# Altium schematic sheet PowerModule.SchDoc(PowerModule)
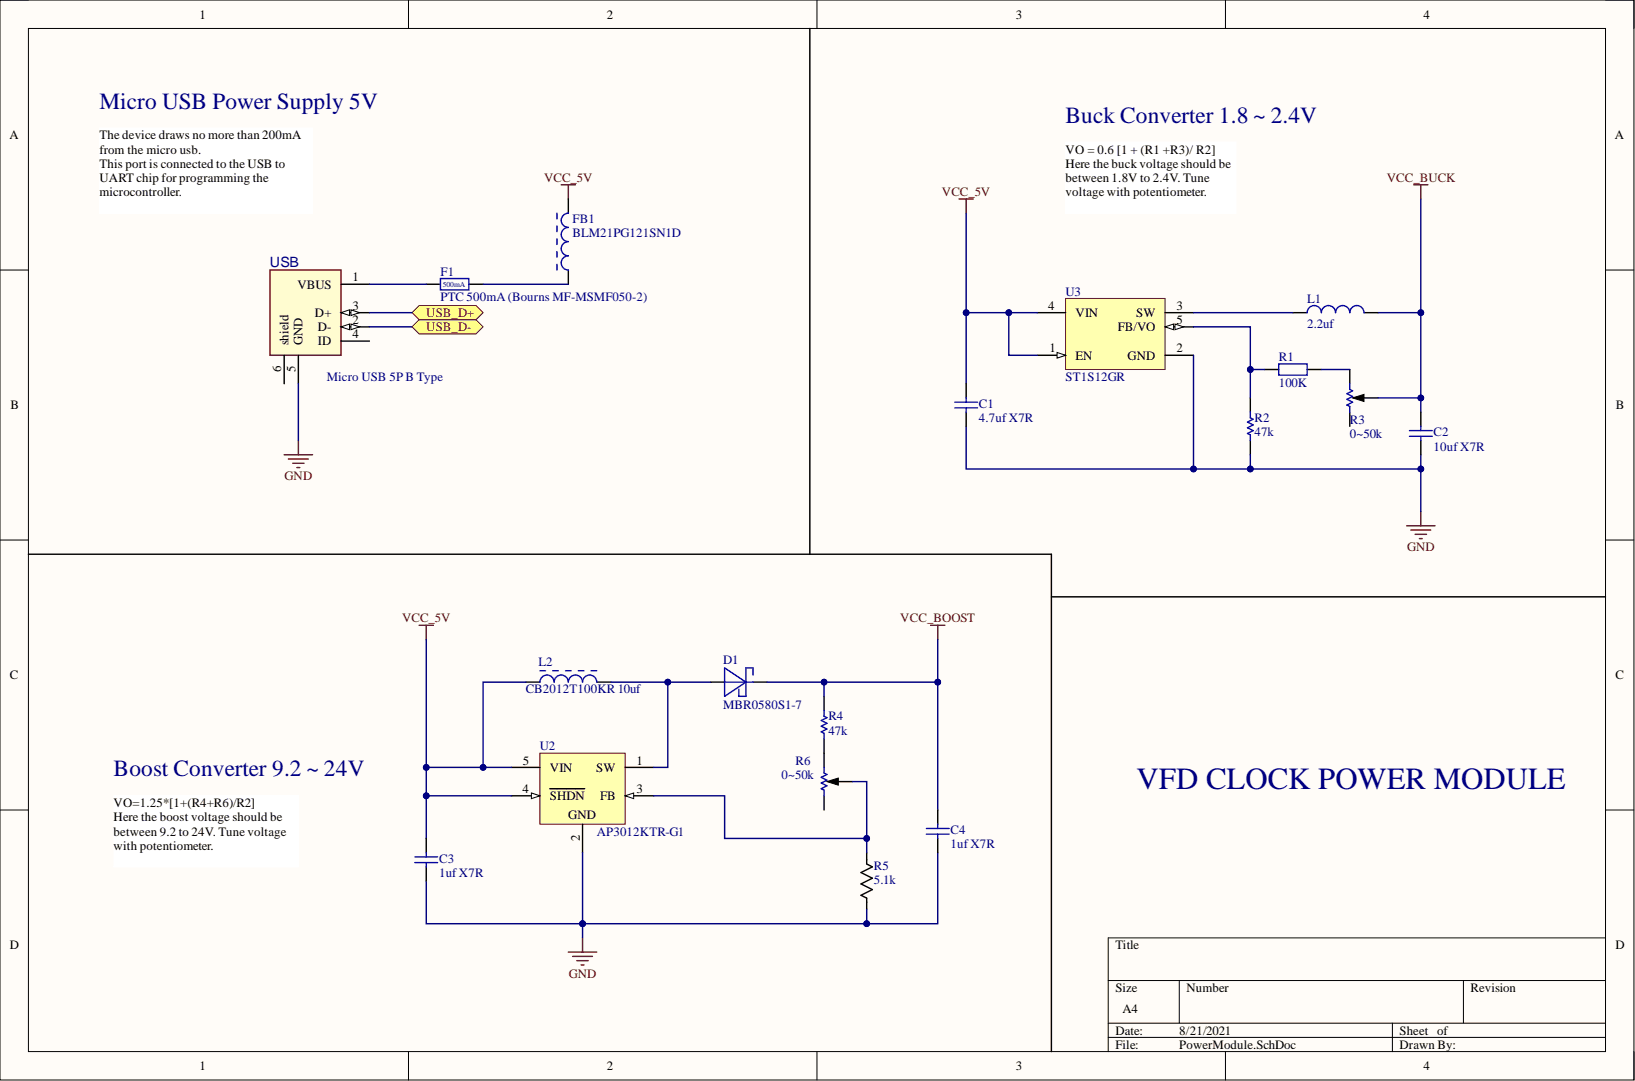
[diagram p0: PowerModule.SchDoc]

## Parts
C1  4.7uf X7R  [PCB-xrh88671zmf2jss4mnlt-1]
C2  10uf X7R  [PCB-xrh88671zmf2jss4mnlt-1]
C3  1uf X7R  Dielectric Characteristic: X7R  [PCB-xrh88671zmf2jss4mnlt-1]
C4  1uf X7R  Dielectric Characteristic: X7R  [PCB-xrh88671zmf2jss4mnlt-1]
D1  MBR0580S1-7  [PCB-x9u2r4ha3xm1g26stesz-1]
F1  PTC 500mA (Bourns MF-MSMF050-2)  [PCB-jtgmhik4xw0hzz22xc7c-1]
FB1  BLM21PG121SN1D  [PCB-2tlhtcllslu2k50oule1-1]
L1  2.2uf  [PCB-x314rydh028eodagaqn6-1]
L2  CB2012T100KR 10uf  [PCB-azxz2krz5wef70fntus1-1]
R1  100K  [PCB-3656032-1]
R2  47k  [PCB-b4z3kml9vja5p4iafh7f-1]
R3  0~50k  [PCB-bw0neadmiyqt3ahpjs36-1]
R4  47k  [PCB-0002-00377-5]
R5  5.1k  [PCB-0002-00380-1]
R6  0~50k  [PCB-bw0neadmiyqt3ahpjs36-1]
U2  AP3012KTR-G1  [PCB-9ejrgpl03flg5xvo1qej-1]
U3  ST1S12GR  [TSOT23-5L_M]
USB  Micro USB 5P B Type  [PCB-edlm2od7brxgpj78b9fm-1]

## Ports
USB_D+, USB_D-

## Nets
GND: C1.1 C2.1 C3.1 C4.1 R2.1 R5.1 U2.2 U3.2(GND) USB.5(GND)
VCC_5V: C1.2 C3.2 FB1.2 L2.1 U2.4(SHDN) U2.5(VIN) U3.1(EN) U3.4(VIN)
VCC_BOOST: C4.2 D1.1 R4.2
VCC_BUCK: C2.2 L1.2 R3.2
NetD1_2: D1.2 L2.2 U2.1(SW)
NetF1_1: F1.1 USB.1(VBUS)
NetF1_2: F1.2 FB1.1
NetL1_1: L1.1 U3.3(SW)
NetR1_1: R1.1 R2.2 U3.5(FB/VO)
NetR1_2: R1.2 R3.1
NetR4_1: R4.1 R6.1
NetR5_2: R5.2 R6.2 U2.3(FB)
NetUSB_2: USB.2(D-)
NetUSB_3: USB.3(D+)

Not connected: R3 3; R6 3; USB 4(ID) 6(shield)

## Library data of the parts
C1-C4 = CMP-7104bbe86e53aec3-2: Capacitor [Würth Elektronik] 885012207051 Capacitor; Capacitance: 1.00 µF; Capacitance Tolerance: ±10%; Voltage Rating (DC): 16.0 V; Component Kind: Standard; Library Name: My Personal Space; Manufacturer Part Number: 885012207051; Octopart URL: https://octopart.com/885012207051-w%C3%BCrth+elektronik-65419825; OctopartID: 7104bbe86e53aec3; Packaging: Cut Tape (CT); RoHS: Compliant
  C1 C2: Dielectric Characteristic: X7R
D1 = CMP-f9c833eb294a260f-2: Diode Schottky Barrier Rectifier 80V 0.5A 2-Pin SOD123 T/R; Component Kind: Standard; Library Name: My Personal Space; Lifecycle Status: Not Listed by Manufacturer; Manufacturer Part Number: MBR0580S1-7; Mounting Style: Surface Mount; Octopart URL: https://octopart.com/mbr0580s1-7-diodes+inc.-53081572; OctopartID: f9c833eb294a260f; Packaging: Tape & Reel (TR)
F1 = CMP-277735-10: PTC Resettable Fuse 0.5A(hold) 1A(trip) 15V 100A 0.8W 0.15s 0.15Ohm SMD Solder Pad 1812 T/R; Case/Package (SI): 4532; Lead-Free Status: Lead Free; Operating Voltage: 15.0 V; Power Dissipation: 800 mW; Resistance: 150 mΩ; Time to Trip: 150 ms (max); Tripping Current: 1.00 A; Case/Package: 1812; Component Kind: Standard; Current Rating: 100 A; Digikey: MF-MSMF050-2CT-ND; Holding Current: 500 mA; Library Name: My Personal Space; Manufacturer Part Number: MF-MSMF050-2; Mounting Style: Surface Mount; Octopart URL: https://octopart.com/mf-msmf050-2-bourns-28047; OctopartID: 9a15531fd2fc45d2; Operating Temperature: 85.0 °C (max); Packaging: Tape & Reel (TR), Cut Tape (CT); REACH SVHC Compliance: No SVHC; RoHS: Compliant; Size-Height: 850 µm; Size-Length: 4.55 mm; Size-Width: 3.41 mm; Voltage Rating (DC): 15.0 V
FB1 = CMP-2312463-4: Chip Ferrite Bead for Power Lines, 120 Ohm, 3000 mA, -55 to 125 degC, 2 x 1.25 x 1.05 mm SMD, Tape and Reel; Lead-Free Status: Lead Free; Number of Circuits: 1; Resistance: 120.0 Ω; Component Kind: Standard; Library Name: My Personal Space; Manufacturer Part Number: BLM21PG121SN1D; Octopart Url: https://octopart.com/blm21pg121sn1d-murata-17751448; OctopartID: 2965e161d19bf6a2; REACH SVHC Compliance: No SVHC; RoHS: Compliant; Size-Length: 0.002 m; Size-Width: 0.00125 m
L1 = CMP-b06c7f77ff336fab-1: Bourns CVH201205-2R2M  Inductor Power Shielded Multi-Layer 2.2uH 20% 1MHz Ferrite 0.6A 0.33Ohm DCR 0805 T/R; Component Kind: Standard; Library Name: My Personal Space; Manufacturer Part Number: CVH201205-2R2M; Octopart Url: https://octopart.com/cvh201205-2r2m-bourns-101804601; OctopartID: b06c7f77ff336fab
L2 = CMP-b3277b0f1b366ce0-1: Inductor Power Chip Wirewound 68uH 10% 2.52MHz Ferrite 140mA 7.8Ohm DCR 0805 T/R; Manufacturer Part Number: CB2012T680K; Inductance: 68.0 µH; Lead-Free Status: Lead Free; Self Resonant Frequency: 10.0 MHz; Case/Package: 0805; Component Kind: Standard; Halogen Free Status: Halogen Free; Library Name: My Personal Space; Lifecycle Status: Active; Mounting Style: Surface Mount; Number of Pins: 2; Octopart URL: https://octopart.com/cb2012t680k-taiyo+yuden-19041655; OctopartID: b3277b0f1b366ce0; Packaging: Reel; RoHS: Compliant
R1 = CMP-3656032-9: Thick Film Resistors - SMD 100K 1%; Manufacturer Part Number: CR0805-FX-1003ELF; Case/Package (SI): 2012; Power Rating: 0.125 W; Resistance: 100000.0 Ω; Voltage Rating (DC): 100.0 V; Case/Package: 0805; Component Kind: Standard; Library Name: My Personal Space; Mounting Style: Surface Mount; Number of Pins: 2; Octopart Url: https://octopart.com/cr0805-fx-1003elf-bourns-982211; OctopartID: b2b07bb46b156d77; REACH SVHC Compliance: No SVHC; Resistance Tolerance: ±1%; RoHS: Compliant; Size-Length: 0.0020066 m; Size-Thickness: 0.000508 m; Size-Width: 0.0012446 m
R2 = CMP-39acf6c9017ee66b-1: Resistor; ResHighPower 0805 330R 1% 1/2W TC100; Manufacturer Part Number: CMP0805-FX-3300ELF; Value: 330 Ω; Component Kind: Standard; Library Name: My Personal Space; Octopart URL: https://octopart.com/cmp0805-fx-3300elf-bourns-107945396; OctopartID: 39acf6c9017ee66b
R3 R6 = CMP-13cdd9aad93acc0a-3: Res Cermet Trimmer 50K Ohm 10% 1/2W 1(Elec)/1(Mech)Turn 2.6mm (7 X 7 X 5.8mm) Pin Thru-Hole Bulk; Manufacturer Part Number: CT-6EP503; Lead-Free Status: Lead Free; Power Rating: 500 mW; Resistance: 50.0 kΩ; Temperature Coefficient: -100 ppm/°C to 100 ppm/°C; Color: Blue; Component Kind: Standard; Library Name: My Personal Space; Mounting Style: Through Hole; Octopart URL: https://octopart.com/ct-6ep503-nidec+copal-91739835; OctopartID: 99aa55d76a77466d; Operating Temperature: 120 °C (max); Packaging: Bulk; Resistance Tolerance: ±10%; RoHS: Compliant; Size-Height: 5.80 mm; Size-Length: 7.00 mm; Size-Width: 7.00 mm; Weight: 510 mg
R4 = CMP-0eb2c5cc96c2d175-6: Res Thick Film 0805 47K Ohm 1% 1/8W ±100ppm/°C Molded SMD SMD Paper T/R; Manufacturer Part Number: RC0805FR-0747KL; Case/Package (SI): 2012; Lead-Free Status: Lead Free; Power Rating: 125 mW; Resistance: 47.0 kΩ; Temperature Coefficient: -100 ppm/°C to 100 ppm/°C; Voltage Rating (DC): 150 V; Case/Package: 0805; Component Kind: Standard; Library Name: My Personal Space; Mounting Style: Surface Mount; Number of Pins: 2; Octopart URL: https://octopart.com/rc0805fr-0747kl-yageo-41205014; OctopartID: 0eb2c5cc96c2d175; Operating Temperature: 155 °C (max); Packaging: Tape & Reel; REACH SVHC Compliance: No SVHC; Resistance Tolerance: ±1%; RoHS: Compliant; Size-Height: 500 µm; Size-Length: 2.00 mm; Size-Width: 1.25 mm
R5 = CMP-9b27523ffbe444e0-4: Res Thick Film 0805 5.1K Ohm 1% 0.125W(1/8W) ±100ppm/C Epoxy Pad SMD T/R; Manufacturer Part Number: RC0805FR-075K1L; Case/Package (SI): 2012; Lead-Free Status: Lead Free; Power Rating: 125 mW; Resistance: 5.10 kΩ; Temperature Coefficient: -100 ppm/°C to 100 ppm/°C; Voltage Rating (DC): 150 V; Case/Package: 0805; Component Kind: Standard; Library Name: My Personal Space; Lifecycle Status: Not Listed by Manufacturer; Mounting Style: Surface Mount; Octopart URL: https://octopart.com/rc0805fr-075k1l-yageo-1197477; OctopartID: 9b27523ffbe444e0; Operating Temperature: 155 °C (max); Packaging: Tape & Reel; Resistance Tolerance: ±1%; RoHS: Compliant; Size-Height: 500 µm; Size-Length: 2.00 mm; Size-Width: 1.25 mm
U2 = CMP-576a9fb826ae88ad-7: AP3012 Series 16 V 500 mA 1.5 MHz Surface Mount Boost DC-DC Converter - SOT-25; Lead-Free Status: Lead Free; Component Kind: Standard; Library Name: My Personal Space; Lifecycle Status: Not Listed by Manufacturer; Manufacturer Part Number: AP3012KTR-G1; Mounting Style: Surface Mount; Octopart URL: https://octopart.com/ap3012ktr-g1-diodes+inc.-30954658; OctopartID: 576a9fb826ae88ad; Packaging: Tape & Reel (TR); RoHS: Compliant
U3 = CMP-1483042-2: Synchronous rectification with inhibit, 0.7 A, 1.7 MHz adjustable, step-down switching regulator, 5-Pin TSOT, Tape and Reel; Lead-Free Status: Lead Free; Number of Outputs: 1; Output Current: 0.7 A; Component Kind: Standard; Library Name: My Personal Space; Lifecycle Status: Active; Manufacturer Part Number: ST1S12GR; Number of Pins: 5; Octopart Url: https://octopart.com/st1s12gr-stmicroelectronics-11547753; OctopartID: a1b4680eb0bb7e73; RoHS: Compliant
USB = CMP-2509ed477c75face-16: Single Port 5 Contact Horizontal Micro B USB 2.0 Connector; Manufacturer: LCSC; Manufacturer Part Number: C77238; Gender: Female; Component Kind: Standard; Datasheet: https://datasheet.lcsc.com/szlcsc/LCSC-micro-5PAll-posts-are-not-guided-Flat-welding-High-temperature_C77238.pdf; Library Name: My Personal Space; Mounting Style: Surface Mount; OctopartID: 2509ed477c75face
